# Altium schematic sheet [1] Shield.SchDoc
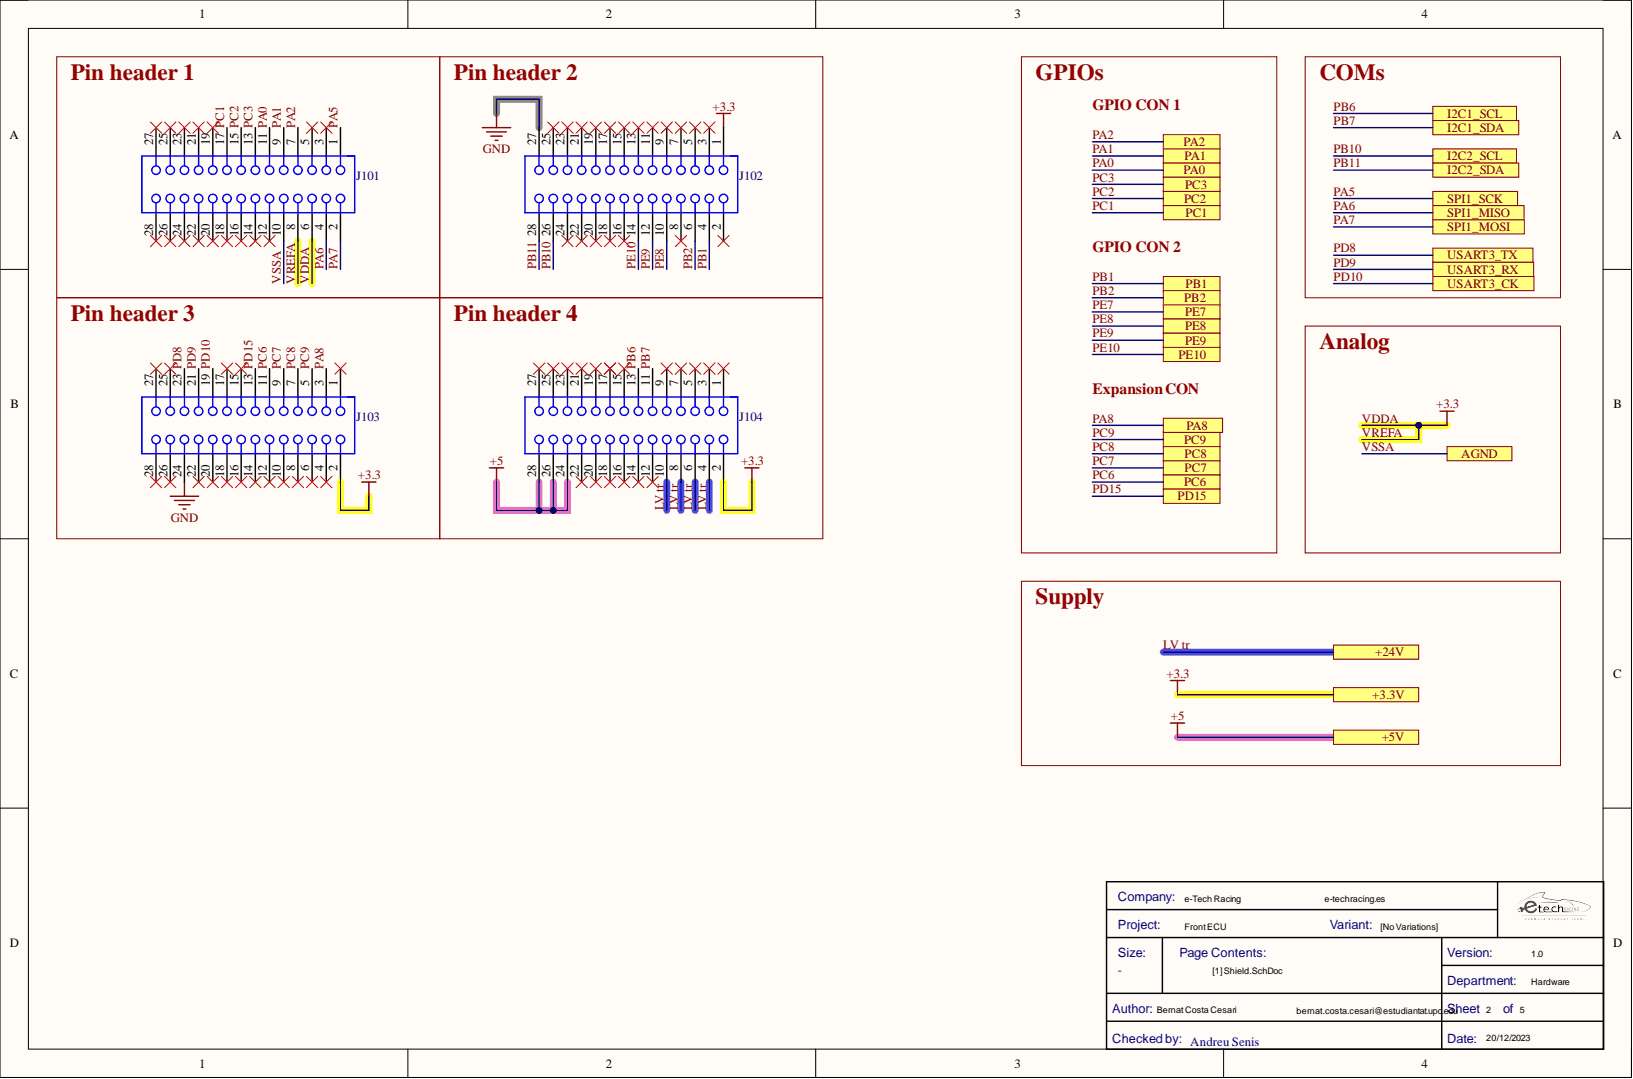
[diagram p0: [1] Shield.SchDoc]

## Parts
J101  62332821021  [62332821021]  (value not drawn)
J102  62332821021  [62332821021]  (value not drawn)
J103  62332821021  [62332821021]  (value not drawn)
J104  62332821021  [62332821021]  (value not drawn)

## Ports
+3.3V, +5V, +24V, AGND, I2C1_SCL, I2C1_SDA, I2C2_SCL, I2C2_SDA, PA0, PA1, PA2, PA8, PB1, PB2, PC1, PC2, PC3, PC6, PC7, PC8, PC9, PD15, PE7, PE8, PE9, PE10, SPI1_MISO, SPI1_MOSI, SPI1_SCK, USART3_CK, USART3_RX, USART3_TX

## Nets
GND: J102.27 J103.24
+5: J104.24 J104.26 J104.28 ; port +5V
LV tr: J104.4 J104.6 J104.8 J104.10 ; port +24V
PA0: J101.11 ; port PA0
PA1: J101.9 ; port PA1
PA2: J101.7 ; port PA2
PA5: J101.1 ; port SPI1_SCK
PA6: J101.4 ; port SPI1_MISO
PA7: J101.2 ; port SPI1_MOSI
PA8: J103.3 ; port PA8
PB1: J102.4 ; port PB1
PB2: J102.6 ; port PB2
PB6: J104.13 ; port I2C1_SCL
PB7: J104.11 ; port I2C1_SDA
PB10: J102.26 ; port I2C2_SCL
PB11: J102.28 ; port I2C2_SDA
PC1: J101.17 ; port PC1
PC2: J101.15 ; port PC2
PC3: J101.13 ; port PC3
PC6: J103.11 ; port PC6
PC7: J103.9 ; port PC7
PC8: J103.7 ; port PC8
PC9: J103.5 ; port PC9
PD8: J103.23 ; port USART3_TX
PD9: J103.21 ; port USART3_RX
PD10: J103.19 ; port USART3_CK
PD15: J103.13 ; port PD15
PE8: J102.10 ; port PE8
PE9: J102.12 ; port PE9
PE10: J102.14 ; port PE10
VDDA: J101.6 J101.8 J102.1 J103.2 J104.2 ; port +3.3V
VSSA: J101.10 ; port AGND
NetJ101_3: J101.3
NetJ101_5: J101.5
NetJ101_12: J101.12
NetJ101_14: J101.14
NetJ101_16: J101.16
NetJ101_18: J101.18
NetJ101_19: J101.19
NetJ101_20: J101.20
NetJ101_21: J101.21
NetJ101_22: J101.22
NetJ101_23: J101.23
NetJ101_24: J101.24
NetJ101_25: J101.25
NetJ101_26: J101.26
NetJ101_27: J101.27
NetJ101_28: J101.28
NetJ102_2: J102.2
NetJ102_3: J102.3
NetJ102_5: J102.5
NetJ102_7: J102.7
NetJ102_8: J102.8
NetJ102_9: J102.9
NetJ102_11: J102.11
NetJ102_13: J102.13
NetJ102_15: J102.15
NetJ102_16: J102.16
NetJ102_17: J102.17
NetJ102_18: J102.18
NetJ102_19: J102.19
NetJ102_20: J102.20
NetJ102_21: J102.21
NetJ102_22: J102.22
NetJ102_23: J102.23
NetJ102_24: J102.24
NetJ102_25: J102.25
NetJ103_1: J103.1
NetJ103_4: J103.4
NetJ103_6: J103.6
NetJ103_8: J103.8
NetJ103_10: J103.10
NetJ103_12: J103.12
NetJ103_14: J103.14
NetJ103_15: J103.15
NetJ103_16: J103.16
NetJ103_17: J103.17
NetJ103_18: J103.18
NetJ103_20: J103.20
NetJ103_22: J103.22
NetJ103_25: J103.25
NetJ103_26: J103.26
NetJ103_27: J103.27
NetJ103_28: J103.28
NetJ104_1: J104.1
NetJ104_3: J104.3
NetJ104_5: J104.5
NetJ104_7: J104.7
NetJ104_9: J104.9
NetJ104_12: J104.12
NetJ104_14: J104.14
NetJ104_15: J104.15
NetJ104_16: J104.16
NetJ104_17: J104.17
NetJ104_18: J104.18
NetJ104_19: J104.19
NetJ104_20: J104.20
NetJ104_21: J104.21
NetJ104_22: J104.22
NetJ104_23: J104.23
NetJ104_25: J104.25
NetJ104_27: J104.27
PE7: ; port PE7

## Library data of the parts
J101-J104 = 62332821021: WR-PHD Socket Header, SMT, Vertical, pitch 1.27mm, 2 Row, 28P; Manufacturer: Wurth Elektronik; Contact Resistance: 20mΩ; Rated Current: 1A; Withstanding Voltage: 500V; Working Voltage: 250V; Category: Board-to-Board Connectors; Component Kind: Standard; ComponentLink1Description: Website Link; ComponentLink1URL: https://www.we-online.com/redexpert/article/62332821021?ad; ComponentLink2Description: Datasheet Link; ComponentLink2URL: https://www.we-online.com/redexpert/spec/62332821021?ad; Gender: Socket Header; Library Name: Electromechanical.SchLib; Manufacturer Part Number: 62332821021; Match Code: WR-PHD; Mount: Surface Mount; Number of Pins: 28; Number of Rows: 2; Operating Temperature Max: 105°C; Operating Temperature Min: -40°C; Orientation: Vertical; Packaging: Tape and Reel; Pitch: 1.27mm
